# Altium schematic sheet ARK_CAN_GPS_Microcontroller.SchDoc(ARK_CAN_GPS_Microcontroller)
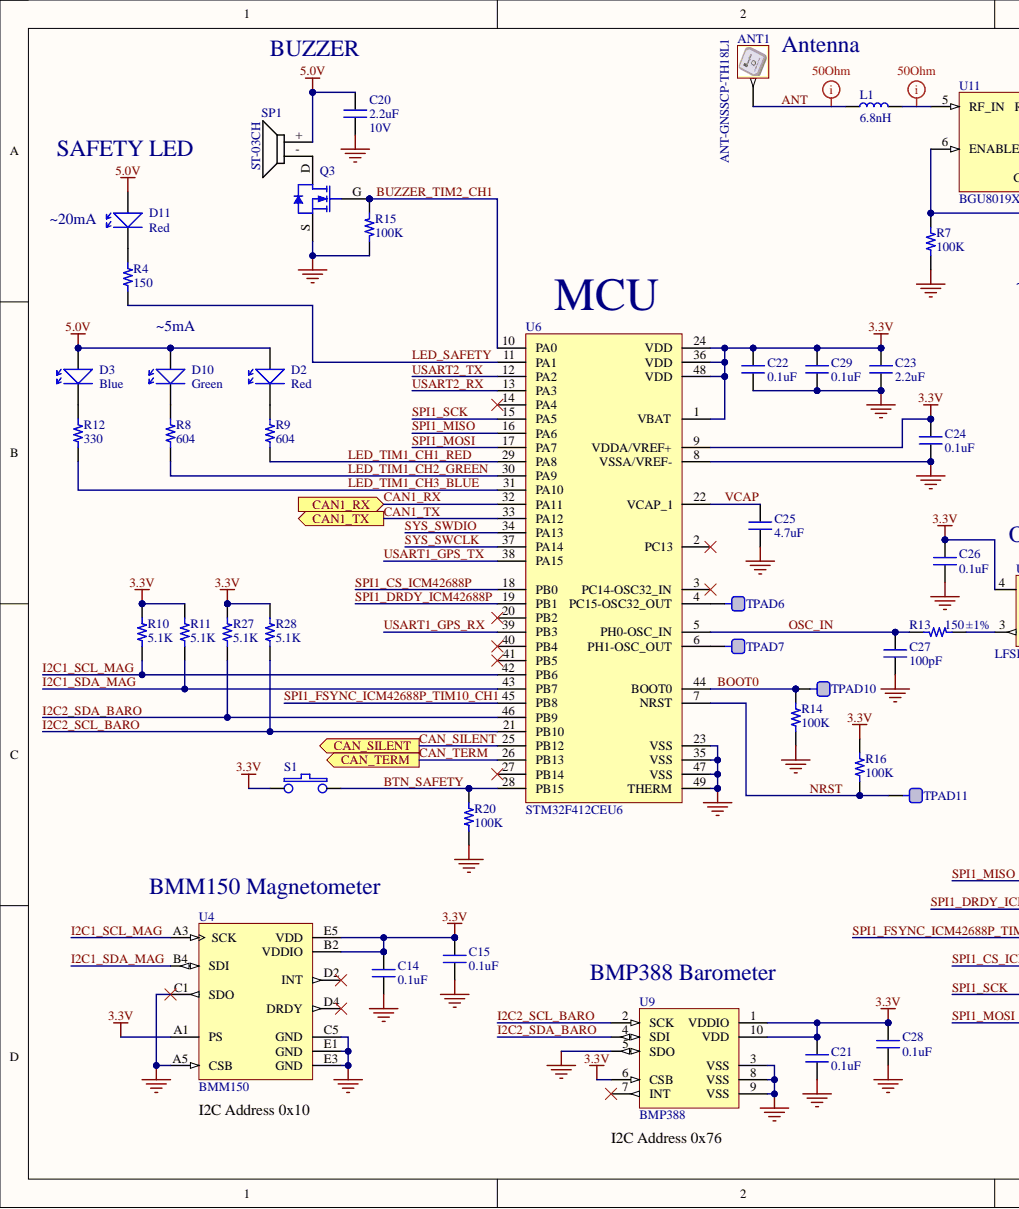
[diagram p0: ARK_CAN_GPS_Microcontroller.SchDoc, left]
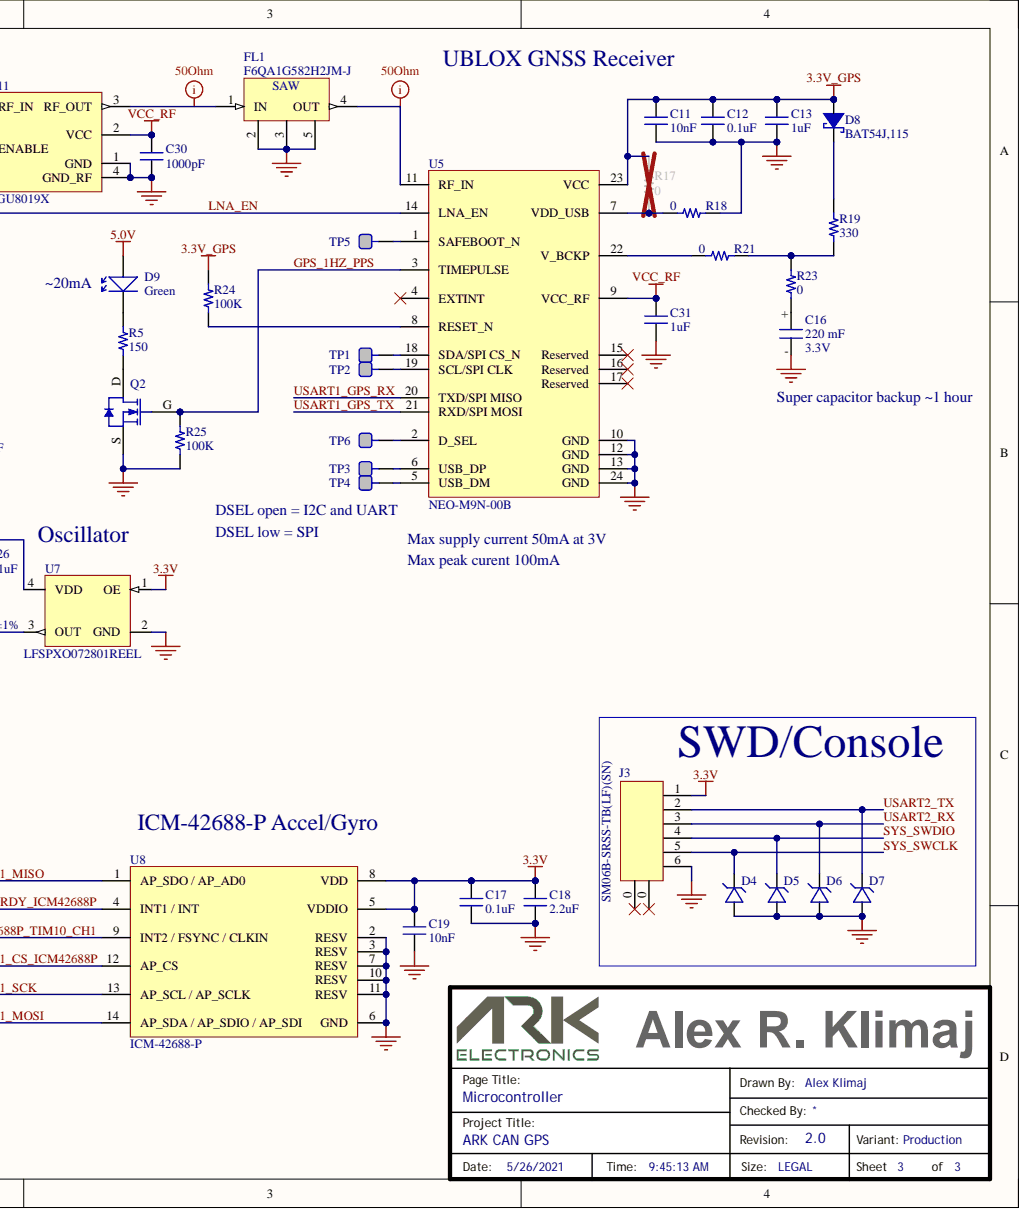
[diagram p1: ARK_CAN_GPS_Microcontroller.SchDoc, right]

## Parts
ANT1  ANT-GNSSCP-TH18L1  [ANT-GNSSCP-TH18L1]
C11  value 10nF  [CAP 0402/1005]  (hidden comment: Capacitor)
C12  0.1uF  [CAP 0402/1005]
C13  1uF  [CAP 0402/1005]
C14  0.1uF  [CAP 0402/1005]
C15  0.1uF  [CAP 0402/1005]
C16  value 220 mF  Voltage_Rating: 3.3V  [DSK-3R3H224U-HL]  (hidden comment: DCK-3R3E224U-E)
C17  0.1uF  [CAP 0402/1005]
C18  2.2uF  [CAP 0402/1005]
C19  10nF  [CAP 0402/1005]
C20  2.2uF  Voltage_Rating: 10V  [CAP 0402/1005]
C21  0.1uF  [CAP 0402/1005]
C22  value 0.1uF  [CAP 0402/1005]  (hidden comment: Capacitor)
C23  value 2.2uF  [CAP 0402/1005]  (hidden comment: Capacitor)
C24  value 0.1uF  [CAP 0402/1005]  (hidden comment: Capacitor)
C25  4.7uF  [CAP 0402/1005]
C26  value 0.1uF  [CAP 0402/1005]  (hidden comment: Capacitor)
C27  value 100pF  [CAP 0402/1005]  (hidden comment: Capacitor)
C28  0.1uF  [CAP 0402/1005]
C29  value 0.1uF  [CAP 0402/1005]  (hidden comment: Capacitor)
C30  1000pF  [CAP 0402/1005]
C31  1uF  [CAP 0402/1005]
D2  LTST-C190KRKT  [LTST-C191TBKT]  (value not drawn)
D3  LTST-C191TBKT  [LTST-C191TBKT]  (value not drawn)
D4  TVS Diode  [SOD-923]  (value not drawn)
D5  TVS Diode  [SOD-923]  (value not drawn)
D6  TVS Diode  [SOD-923]  (value not drawn)
D7  TVS Diode  [SOD-923]  (value not drawn)
D8  BAT54J,115  [SOD323]
D9  LTST-C191KGKT  [LTST-C191TBKT]  (value not drawn)
D10  LTST-C191KGKT  [LTST-C191TBKT]  (value not drawn)
D11  LTST-C190KRKT  [LTST-C191TBKT]  (value not drawn)
FL1  F6QA1G582H2JM-J  [F6QA1G582H2JM-J]
J3  SM06B-SRSS-TB(LF)(SN)  [SM06B-SRSS-TB(LF)(SN)]
L1  value 6.8nH  [Ind 0402/1005]
Q2  PMV130ENEAR  [SOT23 DIODES]  (value not drawn)
Q3  PMV130ENEAR  [SOT23 DIODES]  (value not drawn)
R4  150  [RESC0402(1005)_N]
R5  value 150  [RESC0402(1005)_N]  (hidden comment: Resistor)
R7  value 100K  [RESC0402(1005)_N]  (hidden comment: Resistor)
R8  604  [RESC0402(1005)_N]
R9  604  [RESC0402(1005)_N]
R10  value 5.1K  [RESC0402(1005)_N]  (hidden comment: Resistor)
R11  value 5.1K  [RESC0402(1005)_N]  (hidden comment: Resistor)
R12  330  [RESC0402(1005)_N]
R13  150  Tolerance: ±1%  [RESC0402(1005)_N]
R14  100K  [RESC0402(1005)_N]
R15  value 100K  [RESC0402(1005)_N]  (hidden comment: Resistor)
R16  100K  [RESC0402(1005)_N]
R17  0  [RESC0402(1005)_N]
R18  0  [RESC0402(1005)_N]
R19  330  [RESC0402(1005)_N]
R20  value 100K  [RESC0402(1005)_N]  (hidden comment: Resistor)
R21  0  [RESC0402(1005)_N]
R23  0  [RESC0402(1005)_N]
R24  value 100K  [RESC0402(1005)_N]  (hidden comment: Resistor)
R25  value 100K  [RESC0402(1005)_N]  (hidden comment: Resistor)
R27  value 5.1K  [RESC0402(1005)_N]  (hidden comment: Resistor)
R28  value 5.1K  [RESC0402(1005)_N]  (hidden comment: Resistor)
S1  SW PUSHBUTTON  [PTS 647 SK38 SMTR2 LFS]  (value not drawn)
SP1  ST-03CH  [ST-03CH]
TP1  TEST_PAD  [TEST_PAD]  (value not drawn)  (no BOM)
TP2  TEST_PAD  [TEST_PAD]  (value not drawn)  (no BOM)
TP3  TEST_PAD  [TEST_PAD]  (value not drawn)  (no BOM)
TP4  TEST_PAD  [TEST_PAD]  (value not drawn)  (no BOM)
TP5  TEST_PAD  [TEST_PAD]  (value not drawn)  (no BOM)
TP6  TEST_PAD  [TEST_PAD]  (value not drawn)  (no BOM)
TPAD6  TEST_PAD  [TEST_PAD]  (value not drawn)  (no BOM)
TPAD7  TEST_PAD  [TEST_PAD]  (value not drawn)  (no BOM)
TPAD10  TEST_PAD  [TEST_PAD]  (value not drawn)  (no BOM)
TPAD11  TEST_PAD  [TEST_PAD]  (value not drawn)  (no BOM)
U4  BMM150  [XDCR_BMM150]
U5  NEO-M9N-00B  [NEO-D9S]
U6  STM32F412CEU6  [UFQFPN48]
U7  LFSPXO072801REEL  Voltage_Rating: 3.3V  [LFSPXO072801REEL]
U8  ICM-42688-P  [ICM-42688-P]
U9  BMP388  [BMP388]
U11  BGU8019X  [TSNP-6-2]

## Ports
CAN1_RX, CAN1_TX, CAN_SILENT, CAN_TERM

## Nets
3.3V: C14.2 C15.2 C17.2 C18.2 C19.2 C21.2 C22.2 C23.2 C24.2 C26.2 C28.2 C29.2 J3.1 R10.2 R11.2 R16.2 R27.2 R28.2 S1.2 U4.A1(PS) U4.B2(VDDIO) U4.E5(VDD) U6.1(VBAT) U6.9(VDDA/VREF+) U6.24(VDD) U6.36(VDD) U6.48(VDD) U7.1(OE) U7.4(VDD) U8.5(VDDIO) U8.8(VDD) U9.1(VDDIO) U9.6(CSB) U9.10(VDD)
3.3V_GPS: C11.2 C12.2 C13.2 D8.2 R17.2 R24.2 U5.23(VCC)
5.0V: C20.2 D2.2 D3.2 D9.2 D10.2 D11.2 SP1.+
GND: C11.1 C12.1 C13.1 C14.1 C15.1 C16.- C17.1 C18.1 C19.1 C20.1 C21.1 C22.1 C23.1 C24.1 C25.1 C26.1 C27.1 C28.1 C29.1 C30.1 C31.1 D4.2 D5.2 D6.2 D7.2 FL1.2(IN) FL1.3 FL1.5 J3.6 Q2.S Q3.S R7.1 R14.1 R15.2 R18.2 R20.1 R25.1 U4.A5(CSB) U4.C1(SDO) U4.C5(GND) U4.E1(GND) U4.E3(GND) U5.10(GND) U5.12(GND) U5.13(GND) U5.24(GND) U6.8(VSSA/VREF-) U6.23(VSS) U6.35(VSS) U6.47(VSS) U6.49(THERM) U7.2(GND) U8.2(RESV) U8.3(RESV) U8.6(GND) U8.7(RESV) U8.10(RESV) U8.11(RESV) U9.3(VSS) U9.5(SDO) U9.8(VSS) U9.9(VSS) U11.1(GND) U11.4(GND_RF)
VCC_RF: C30.2 C31.2 U5.9(VCC_RF) U11.2(VCC)
ANT: ANT1.1 L1.1
BOOT0: R14.2 TPAD10.1 U6.44(BOOT0)
BTN_SAFETY: R20.2 S1.1 U6.28(PB15)
BUZZER_TIM2_CH1: Q3.G R15.1 U6.10(PA0)
CAN1_RX: U6.32(PA11) ; port CAN1_RX
CAN1_TX: U6.33(PA12) ; port CAN1_TX
CAN_SILENT: U6.25(PB12) ; port CAN_SILENT
CAN_TERM: U6.26(PB13) ; port CAN_TERM
GPS_1HZ_PPS: Q2.G R25.2 U5.3(TIMEPULSE)
I2C1_SCL_MAG: R10.1 U4.A3(SCK) U6.42(PB6)
I2C1_SDA_MAG: R11.1 U4.B4(SDI) U6.43(PB7)
I2C2_SCL_BARO: R28.1 U6.21(PB10) U9.2(SCK)
I2C2_SDA_BARO: R27.1 U6.46(PB9) U9.4(SDI)
LED_SAFETY: R4.1 U6.11(PA1)
LED_TIM1_CH1_RED: R9.1 U6.29(PA8)
LED_TIM1_CH2_GREEN: R8.1 U6.30(PA9)
LED_TIM1_CH3_BLUE: R12.1 U6.31(PA10)
LNA_EN: R7.2 U5.14(LNA_EN) U11.6(ENABLE)
NRST: R16.1 TPAD11.1 U6.7(NRST)
OSC_IN: C27.2 R13.1 U6.5(PH0-OSC_IN)
SPI1_CS_ICM42688P: U6.18(PB0) U8.12(AP_CS)
SPI1_DRDY_ICM42688P: U6.19(PB1) U8.4(INT1_/_INT)
SPI1_FSYNC_ICM42688P_TIM10_CH1: U6.45(PB8) U8.9
SPI1_MISO: U6.16(PA6) U8.1(AP_SDO_/_AP_AD0)
SPI1_MOSI: U6.17(PA7) U8.14
SPI1_SCK: U6.15(PA5) U8.13(AP_SCL_/_AP_SCLK)
SYS_SWCLK: D4.1 J3.5 U6.37(PA14)
SYS_SWDIO: D5.1 J3.4 U6.34(PA13)
USART1_GPS_RX: U5.20(TXD/SPI_MISO) U6.39(PB3)
USART1_GPS_TX: U5.21(RXD/SPI_MOSI) U6.38(PA15)
USART2_RX: D6.1 J3.3 U6.13(PA3)
USART2_TX: D7.1 J3.2 U6.12(PA2)
VCAP: C25.2 U6.22(VCAP_1)
NetC16_+: C16.+ R23.1
NetD2_1: D2.1 R9.2
NetD3_1: D3.1 R12.2
NetD8_1: D8.1 R19.2
NetD9_1: D9.1 R5.2
NetD10_1: D10.1 R8.2
NetD11_1: D11.1 R4.2
NetFL1_1: FL1.1 U11.3(RF_OUT)
NetFL1_4: FL1.4(OUT) U5.11(RF_IN)
NetL1_2: L1.2 U11.5(RF_IN)
NetQ2_D: Q2.D R5.1
NetQ3_D: Q3.D SP1.-
NetR13_2: R13.2 U7.3(OUT)
NetR17_1: R17.1 R18.1 U5.7(VDD_USB)
NetR19_1: R19.1 R21.2 R23.2
NetR21_1: R21.1 U5.22(V_BCKP)
NetR24_1: R24.1 U5.8(RESET_N)
NetTP1_1: TP1.1 U5.18(SDA/SPI_CS_N)
NetTP2_1: TP2.1 U5.19(SCL/SPI_CLK)
NetTP3_1: TP3.1 U5.6(USB_DP)
NetTP4_1: TP4.1 U5.5(USB_DM)
NetTP5_1: TP5.1 U5.1(SAFEBOOT_N)
NetTP6_1: TP6.1 U5.2(D_SEL)
NetTPAD6_1: TPAD6.1 U6.4(PC15-OSC32_OUT)
NetTPAD7_1: TPAD7.1 U6.6(PH1-OSC_OUT)

Not connected: J3 0; U4 D2(INT) D4(DRDY); U5 4(EXTINT) 15(Reserved) 16(Reserved) 17(Reserved); U6 2(PC13) 3(PC14-OSC32_IN) 14(PA4) 20(PB2) 27(PB14) 40(PB4) 41(PB5); U9 7(INT)

## Library data of the parts
ANT1 = 31085: Manufacturer: Linx Technologies Inc.; Mfr Part Description: RF ANT GNSS PATCH L1 18X18 PIN; Part_Type: RF; Database Table Name: Parts; Power_Rating: 8 W; Updated By: aklimaj; Component Kind: Standard; ComponentLink1Description: Datasheet; ComponentLink1URL: https://linxtechnologies.com/wp/wp-content/uploads/ant-gnsscp-th18l1-ds.pdf; ComponentLink2Description: Supplier Part Details; ComponentLink2URL: https://www.digikey.com/en/products/detail/linx-technologies-inc/ANT-GNSSCP-TH18L1/12686184; Created_Date: 2021/05/04 14:26:32; ID: 31085; Library Name: Parts.DbLib; LifeCycle: New; Mfr Part Number: ANT-GNSSCP-TH18L1; RoHS: Yes; Supplier: Digi-Key; Supplier Part Number: 343-ANT-GNSSCP-TH18L1-ND; Updated_Date: 2021/05/04 15:27:27; Value: ANT-GNSSCP-TH18L1; Created By: aklimaj
C11 C19 = 30018: SMT Capacitor; Manufacturer: Samsung; Mfr Part Description: CAP CER 10000pF ±10% 25V X7R 0402 (1005 Metric); Mfr Part Number: CL05B103KA5NNNC; Database Table Name: Parts; Dielectric: X7R; Tolerance: ±10%; Updated By: aklimaj; Voltage_Rating: 25V; Component Kind: Standard; ComponentLink1Description: Datasheet; ComponentLink1URL: http://www.samsungsem.com/kr/support/product-search/mlcc/__icsFiles/afieldfile/2016/08/18/S_CL05B103KA5NNNC.pdf; ComponentLink2Description: Supplier Part Details; ComponentLink2URL: https://www.digikey.com/product-detail/en/samsung-electro-mechanics-america-inc/CL05B103KA5NNNC/1276-1057-1-ND/3889143; Created_Date: 2017/07/13 10:07:08; ID: 30018; Library Name: Parts.DbLib; LifeCycle: New; Package_Case: 0402 (1005 Metric); Part_Type: Capacitor; RoHS: Yes; Supplier: Digi-Key; Supplier Part Number: 1276-1057-1-ND; Temperature Range: -55C to +125C; Updated_Date: 2017/07/13 10:07:58; Created By: aklimaj
  C19: Value: 10nF
C12 C14 C15 C17 C21 C22 C24 C26 C28 C29 = 30303: SMT Capacitor; Manufacturer: Samsung Electro-Mechanics; Mfr Part Description: CAP CER 0.1uF ±10% 10V X5R 0402 (1005 Metric); Mfr Part Number: CL05A104KP5NNNC; Database Table Name: Parts; Dielectric: X5R; Tolerance: ±10%; Updated By: aklimaj; Voltage_Rating: 10V; Component Kind: Standard; ComponentLink1Description: Datasheet; ComponentLink1URL: http://www.samsungsem.com/kr/support/product-search/mlcc/CL05A104KP5NNNC.jsp; ComponentLink2Description: Supplier Part Details; ComponentLink2URL: https://www.digikey.com/product-detail/en/samsung-electro-mechanics/CL05A104KP5NNNC/1276-1022-1-ND/3889108; Created_Date: 2019/02/28 17:08:36; ID: 30303; Library Name: Parts.DbLib; LifeCycle: New; Package_Case: 0402 (1005 Metric); Part_Type: Capacitor; RoHS: Yes; Supplier: Digi-Key; Supplier Part Number: 1276-1022-1-ND; Temperature Range: -55C to +85C; Updated_Date: 2019/02/28 17:09:37; Created By: aklimaj
  C12 C14 C15 C17 C21 C28: Value: 0.1uF
C13 C31 = 30652: SMT Capacitor; Manufacturer: Samsung Electro-Mechanics; Mfr Part Description: CAP CER 1uF ±10% 10V X5R 0402 (1005 Metric); Mfr Part Number: CL05A105KP5NNNC; Database Table Name: Parts; Dielectric: X5R; Tolerance: ±10%; Updated By: aklimaj; Voltage_Rating: 10V; Component Kind: Standard; ComponentLink1Description: Datasheet; ComponentLink1URL: http://www.samsungsem.com/kr/support/product-search/mlcc/CL05A105KP5NNNC.jsp; ComponentLink2Description: Supplier Part Details; ComponentLink2URL: https://www.digikey.com/product-detail/en/0/1276-1076-1-ND; Created_Date: 2019/11/21 13:38:55; ID: 30652; Library Name: Parts.DbLib; LifeCycle: New; Package_Case: 0402 (1005 Metric); Part_Type: Capacitor; RoHS: Yes; Supplier: Digi-Key; Supplier Part Number: 1276-1076-1-ND; Temperature Range: -55C to +85C; Updated_Date: 2020/08/31 12:38:02; Value: 1uF; Created By: aklimaj
C16 = 31092: Manufacturer: Elna America; Mfr Part Description: CAP 220 mF -20%, +80% 3.3V Coin, Wide Terminals - Opposite Sides; Part_Type: Capacitor; Database Table Name: Parts; Tolerance: -20%, +80%; Updated By: aklimaj; Component Kind: Standard; ComponentLink1Description: Datasheet; ComponentLink1URL: https://media.digikey.com/pdf/Data%20Sheets/Elna%20America%20Inc/DS,DSK.pdf; ComponentLink2Description: Supplier Part Details; ComponentLink2URL: https://www.digikey.com/en/products/detail/elna-america/DSK-3R3H224U-HL/970181?s=N4IgTCBcDaIGwAYAsBaAjAsD0oHIBEQBdAXyA; Created_Date: 2021/05/23 17:43:09; ID: 31092; Library Name: Parts.DbLib; LifeCycle: New; Mfr Part Number: DSK-3R3H224U-HL; Package_Case: Coin, Wide Terminals - Opposite Sides; RoHS: Yes; Supplier: Digi-Key; Supplier Part Number: 604-1020-2-ND; Temperature Range: -10C to +60C; Updated_Date: 2021/05/23 18:11:51; Created By: aklimaj
C18 C23 = 30151: SMT Capacitor; Manufacturer: Murata; Mfr Part Description: CAP CER 2.2uF ±20% 10V X5R 0402 (1005 Metric); Mfr Part Number: GRM155R61A225ME95D; Database Table Name: Parts; Dielectric: X5R; Tolerance: ±20%; Updated By: aklimaj; Voltage_Rating: 10V; Component Kind: Standard; ComponentLink1Description: Datasheet; ComponentLink1URL: http://search.murata.co.jp/Ceramy/image/img/A01X/partnumbering_e_01.pdf; ComponentLink2Description: Supplier Part Details; ComponentLink2URL: https://www.digikey.com/product-detail/en/murata-electronics-north-america/GRM155R61A225ME95D/490-10452-2-ND/5027537; Created_Date: 2017/11/02 14:41:38; ID: 30151; Library Name: Parts.DbLib; LifeCycle: New; Package_Case: 0402 (1005 Metric); Part_Type: Capacitor; RoHS: Yes; Supplier: Digi-Key; Supplier Part Number: 490-10452-2-ND; Temperature Range: -55C to +85C; Updated_Date: 2018/10/19 21:38:16; Created By: aklimaj
  C18: Value: 2.2uF
C20 = 30151: SMT Capacitor; Manufacturer: Murata; Mfr Part Description: CAP CER 2.2uF ±20% 10V X5R 0402 (1005 Metric); Mfr Part Number: GRM155R61A225ME95D; Database Table Name: Parts; Dielectric: X5R; Tolerance: ±20%; Updated By: aklimaj; Component Kind: Standard; ComponentLink1Description: Datasheet; ComponentLink1URL: http://search.murata.co.jp/Ceramy/image/img/A01X/partnumbering_e_01.pdf; ComponentLink2Description: Supplier Part Details; ComponentLink2URL: https://www.digikey.com/product-detail/en/murata-electronics-north-america/GRM155R61A225ME95D/490-10452-2-ND/5027537; Created_Date: 2017/11/02 14:41:38; ID: 30151; Library Name: Parts.DbLib; LifeCycle: New; Package_Case: 0402 (1005 Metric); Part_Type: Capacitor; RoHS: Yes; Supplier: Digi-Key; Supplier Part Number: 490-10452-2-ND; Temperature Range: -55C to +85C; Updated_Date: 2018/10/19 21:38:16; Value: 2.2uF; Created By: aklimaj
C25 = 30302: SMT Capacitor; Manufacturer: TDK Corporation; Mfr Part Description: CAP CER 4.7uF ±10% 6.3V X5R 0402 (1005 Metric); Mfr Part Number: C1005X5R0J475K050BC; Database Table Name: Parts; Dielectric: X5R; Tolerance: ±10%; Updated By: aklimaj; Voltage_Rating: 6.3V; Component Kind: Standard; ComponentLink1Description: Datasheet; ComponentLink1URL: https://product.tdk.com/info/en/catalog/datasheets/mlcc_commercial_general_en.pdf; ComponentLink2Description: Supplier Part Details; ComponentLink2URL: https://www.digikey.com/products/en?keywords=445-5947-1-ND&stock=1; Created_Date: 2019/02/28 17:07:10; ID: 30302; Library Name: Parts.DbLib; LifeCycle: New; Package_Case: 0402 (1005 Metric); Part_Type: Capacitor; RoHS: Yes; Supplier: Digi-Key; Supplier Part Number: 445-5947-1-ND; Temperature Range: -55C to +85C; Updated_Date: 2019/02/28 17:07:25; Value: 4.7uF; Created By: aklimaj
C27 = 30502: SMT Capacitor; Manufacturer: Samsung Electro-Mechanics; Mfr Part Description: CAP CER 100pF ±5% 50V NPO 0402 (1005 Metric); Mfr Part Number: CL05C101JB5NNNC; Database Table Name: Parts; Dielectric: NPO; Tolerance: ±5%; Updated By: aklimaj; Voltage_Rating: 50V; Component Kind: Standard; ComponentLink1Description: Datasheet; ComponentLink1URL: http://www.samsungsem.com/kr/support/product-search/mlcc/CL05C101JB5NNNC.jsp; ComponentLink2Description: Supplier Part Details; ComponentLink2URL: https://www.digikey.com/product-detail/en/samsung-electro-mechanics/CL05C101JB5NNNC/1276-1025-1-ND/3889111; Created_Date: 2019/07/13 19:13:16; ID: 30502; Library Name: Parts.DbLib; LifeCycle: New; Package_Case: 0402 (1005 Metric); Part_Type: Capacitor; RoHS: Yes; Supplier: Digi-Key; Supplier Part Number: 1276-1025-1-ND; Temperature Range: -55C to +125C; Updated_Date: 2019/07/13 19:13:27; Created By: aklimaj
C30 = 30011: SMT Capacitor; Manufacturer: Murata; Mfr Part Description: CAP CER 1000pF ±5% 50V X7R 0402 (1005 Metric); Mfr Part Number: GRM155R71H102JA01J; Database Table Name: Parts; Dielectric: X7R; Tolerance: ±5%; Updated By: aklimaj; Voltage_Rating: 50V; Component Kind: Standard; ComponentLink1Description: Datasheet; ComponentLink1URL: http://search.murata.co.jp/Ceramy/image/img/A01X/partnumbering_e_01.pdf; ComponentLink2Description: Supplier Part Details; ComponentLink2URL: https://www.digikey.com/product-detail/en/murata-electronics-north-america/GRM155R71H102JA01J/490-6349-1-ND/3845546; Created_Date: 2017/07/12 15:36:45; ID: 30011; Library Name: Parts.DbLib; LifeCycle: New; Package_Case: 0402 (1005 Metric); Part_Type: Capacitor; RoHS: Yes; Supplier: Digi-Key; Supplier Part Number: 490-6349-1-ND; Temperature Range: -55C to +125C; Updated_Date: 2017/07/12 15:36:59; Value: 1000pF; Created By: aklimaj
D2 D11 = 30943: Manufacturer: Lite-On Inc.; Mfr Part Description: LED RED CLEAR CHIP SMD; Part_Type: Discrete; Current_Rating: 20mA; Database Table Name: Parts; Updated By: aklimaj; Voltage_Rating: 2V; Component Kind: Standard; ComponentLink1Description: Datasheet; ComponentLink1URL: http://optoelectronics.liteon.com/upload/download/DS-22-99-0151/LTST-C190KRKT.pdf; ComponentLink2Description: Supplier Part Details; ComponentLink2URL: https://www.digikey.com/products/en?keywords=LTST-C190KRKT; Created_Date: 2020/12/11 17:10:57; ID: 30943; Library Name: Parts.DbLib; LifeCycle: New; Mfr Part Number: LTST-C190KRKT; Package_Case: 0603 (1608 Metric); RoHS: Yes; Supplier: Digi-Key; Supplier Part Number: 160-1436-2-ND; Updated_Date: 2020/12/11 17:11:02; Value: LTST-C190KRKT; Created By: aklimaj
D3 = 30059: LTST-C191TBKT LED BLUE CLEAR 0603 SMD; Manufacturer: Lite-On Inc.; Part_Type: Discrete; Current_Rating: 20mA; Database Table Name: Parts; Updated By: aklimaj; Voltage_Rating: 3.3V; Component Kind: Standard; ComponentLink1Description: Datasheet; ComponentLink1URL: http://optoelectronics.liteon.com/upload/download/DS22-2000-230/LTST-C191TBKT.pdf; ComponentLink2Description: Supplier Part Details; ComponentLink2URL: https://www.digikey.com/product-detail/en/lite-on-inc/LTST-C191TBKT/160-1647-1-ND/573587; Created_Date: 2017/09/05 17:40:57; ID: 30059; Library Name: Parts.DbLib; LifeCycle: New; Mfr Part Description: LED BLUE CLEAR 0603 SMD; Mfr Part Number: LTST-C191TBKT; Package_Case: 0603 (1608 Metric); RoHS: Yes; Supplier: Digi-Key; Supplier Part Number: 160-1647-1-ND; Updated_Date: 2018/10/19 21:38:38; Value: LTST-C191TBKT; Created By: aklimaj
D4-D7 = 30166: Manufacturer: ON Semiconductor; Mfr Part Description: TVS DIODE 3.3VWM SOD923; Mfr Part Number: ESD9C3.3ST5G; Part_Type: Protection; Database Table Name: Parts; Updated By: aklimaj; Value: ESD9C3.3ST5G; Voltage_Rating: 3.3V (Max); Component Kind: Standard; ComponentLink1Description: Datasheet; ComponentLink1URL: http://www.onsemi.com/pub/Collateral/ESD9C3.3S-D.PDF; ComponentLink2Description: Supplier Part Details; ComponentLink2URL: https://www.digikey.com/product-detail/en/on-semiconductor/ESD9C3.3ST5G/ESD9C3.3ST5GOSCT-ND/1646498; Created_Date: 2017/12/13 10:54:56; Description: TVS Diode; ID: 30166; Library Name: Parts.DbLib; LifeCycle: New; Package_Case: SOD-923; RoHS: Yes; Supplier: Digi-Key; Supplier Part Number: ESD9C3.3ST5GOSCT-ND; Temperature Range: -55C to +150C (TJ); Updated_Date: 2018/10/19 21:38:12; Created By: aklimaj
D8 = 30022: Diode Schottky 30V 200mA (DC) Surface Mount SOD-323F; Manufacturer: Nexperia USA Inc.; Mfr Part Description: DIODE SCHOTTKY 30V 200MA SOD323F; Part_Type: Discrete; Capacitance @ Vr, F: 10pF @ 1V, 1MHz; Current - Reverse Leakage @ Vr: 2µA @ 25V; Database Table Name: Parts; Speed: Small Signal =< 200mA (Io), Any Speed; Updated By: aklimaj; Voltage - Forward (Vf) (Max) @ If: 800mV @ 100mA; Category: Discrete Semiconductor Products; Component Kind: Standard; ComponentLink1Description: Datasheet; ComponentLink1URL: http://assets.nexperia.com/documents/data-sheet/BAT54J.pdf; ComponentLink2Description: Supplier Part Details; ComponentLink2URL: https://www.digikey.com/products/en?keywords=1727-4818-6-ND&stock=1; Created_Date: 2017/07/13 11:14:24; Current - Average Rectified (Io): 200mA (DC); Current_Rating: 200mA (DC); Diode Type: Schottky; ID: 30022; Library Name: Parts.DbLib; LifeCycle: New; Manufacturer Part Number: BAT54J,115; Mfr Part Number: BAT54J,115; Mounting Type: Surface Mount; Operating Temperature - Junction: 150°C (Max); Package / Case: SC-90, SOD-323F; Package_Case: SC-90, SOD-323F; Packaging: Digi-Reel®; Part Status: Active; Pricing 1: 1=0.37, 10=0.273, 100=0.1545, 500=0.1023, 1000=0.07843 (USD); RoHS: Yes; Stock 1: 25340; Supplier: Digi-Key; Supplier 1: Digi-Key; Supplier Device Package: SOD-323F; Supplier Part Number: 1727-4818-6-ND; Supplier Part Number 1: 1727-4818-6-ND; Temperature Range: 150C (Max); Updated_Date: 2017/07/13 11:17:36; Value: BAT54J,115; Voltage - DC Reverse (Vr) (Max): 30V; Voltage_Rating: 30V; Created By: aklimaj
D9 D10 = 30628: LTST-C191TBKT LED BLUE CLEAR 0603 SMD; Manufacturer: Lite-On Inc.; Mfr Part Description: Green 571nm LED Indication - Discrete 2V 0603 (1608 Metric); Part_Type: Discrete; Current_Rating: 20mA; Database Table Name: Parts; Updated By: aklimaj; Voltage_Rating: 2V; Component Kind: Standard; ComponentLink1Description: Datasheet; ComponentLink1URL: http://optoelectronics.liteon.com/upload/download/DS22-2000-228/LTST-C191KGKT.PDF; ComponentLink2Description: Supplier Part Details; ComponentLink2URL: https://www.digikey.com/product-detail/en/lite-on-inc/LTST-C191KGKT/160-1446-1-ND/386834; Created_Date: 2019/11/13 17:03:39; ID: 30628; Library Name: Parts.DbLib; LifeCycle: New; Mfr Part Number: LTST-C191KGKT; Package_Case: 0603 (1608 Metric); RoHS: Yes; Supplier: Digi-Key; Supplier Part Number: 160-1446-1-ND; Updated_Date: 2019/11/13 17:03:43; Value: LTST-C191KGKT; Created By: aklimaj
FL1 = 30001: F6QA1G582H2JM-J FILTER SAW GPS+GLONASS+COMPASS; Manufacturer: Taiyo Yuden; Database Table Name: Parts; Updated By: aklimaj; Component Kind: Standard; ComponentLink1Description: Datasheet; ComponentLink1URL: http://www.yuden.co.jp/productdata/catalog/en/sawfilter01_e.pdf; ComponentLink2Description: Supplier Part Details; ComponentLink2URL: https://www.digikey.com/product-detail/en/taiyo-yuden/F6QA1G582H2JM-J/587-3990-1-ND/4963980; Created_Date: 2017/07/10 17:26:24; ID: 30001; Library Name: Parts.DbLib; LifeCycle: New; Mfr Part Description: FILTER SAW GPS+GLONASS+COMPASS; Mfr Part Number: F6QA1G582H2JM-J; Package_Case: 5-SMD, No Lead; Part_Type: Filter; RoHS: Yes; Supplier: Digi-Key; Supplier Part Number: 587-3990-1-ND; Updated_Date: 2017/07/12 14:43:03; Value: F6QA1G582H2JM-J; Created By: aklimaj
J3 = 30085: CONN HEADER SH 6POS SIDE 1MM TIN; Manufacturer: JST Sales America Inc.; Part_Type: Connector; Current_Rating: 0.7A; Database Table Name: Parts; Updated By: aklimaj; Voltage_Rating: 50V; Component Kind: Standard; ComponentLink1Description: Datasheet; ComponentLink1URL: http://www.jst-mfg.com/product/pdf/eng/eSH.pdf; ComponentLink2Description: Supplier Part Details; ComponentLink2URL: https://www.digikey.com/product-detail/en/jst-sales-america-inc/SM06B-SRSS-TB(LF)(SN)/455-1806-1-ND/926877; Created_Date: 2017/09/13 10:26:52; ID: 30085; Library Name: Parts.DbLib; LifeCycle: New; Mfr Part Description: CONN HEADER SH 6POS SIDE 1MM TIN; Mfr Part Number: SM06B-SRSS-TB(LF)(SN); RoHS: Yes; Supplier: Digi-Key; Supplier Part Number: 455-1806-1-ND; Temperature Range: -25C to +85C; Updated_Date: 2018/10/19 21:38:32; Value: SM06B-SRSS-TB(LF)(SN); Created By: aklimaj
L1 = 30947: SMT Inductor; Manufacturer: Murata Electronics; Mfr Part Description: INDUCTOR 6.8nH ±2% 1.45A 0402 (1005 Metric); Mfr Part Number: LQW15AN6N8G80D; Current_Rating: 1.45A; Database Table Name: Parts; Tolerance: ±2%; Updated By: aklimaj; Component Kind: Standard; ComponentLink1Description: Datasheet; ComponentLink1URL: https://search.murata.co.jp/Ceramy/image/img/P02/JELF243A-0100.pdf; ComponentLink2Description: Supplier Part Details; ComponentLink2URL: https://www.digikey.com/products/en?keywords=LQW15AN6N8G80D; Created_Date: 2020/12/18 17:42:21; ID: 30947; Library Name: Parts.DbLib; LifeCycle: New; Package_Case: 0402 (1005 Metric); Part_Type: Inductor; RoHS: Yes; Supplier: Digi-Key; Supplier Part Number: 490-14714-2-ND; Temperature Range: -55C to +125C; Updated_Date: 2020/12/18 17:47:41; Created By: aklimaj
Q2 Q3 = 31093: Manufacturer: Nexperia USA Inc.; Mfr Part Description: MOSFET N-CH 40V 2.1A TO236AB; Part_Type: Discrete; Current_Rating: 2.1A (Ta); Database Table Name: Parts; Updated By: aklimaj; Voltage_Rating: 4.5V, 10V; Component Kind: Standard; ComponentLink1Description: Datasheet; ComponentLink1URL: https://assets.nexperia.com/documents/data-sheet/PMV130ENEA.pdf; ComponentLink2Description: Supplier Part Details; ComponentLink2URL: https://www.digikey.com/en/products/detail/nexperia-usa-inc/PMV130ENEAR/5395790; Created_Date: 2021/05/26 09:10:32; ID: 31093; Library Name: Parts.DbLib; LifeCycle: New; Mfr Part Number: PMV130ENEAR; Package_Case: TO-236-3, SC-59, SOT-23-3; RoHS: Yes; Supplier: Digi-Key; Supplier Part Number: 1727-2299-2-ND; Temperature Range: -55C to +150C (TJ); Updated_Date: 2021/05/26 09:10:42; Value: PMV130ENEAR; Created By: aklimaj
R4 R5 = 30328: SMT Resistor; Manufacturer: Yageo; Mfr Part Description: RES 150 Ohm ±1% 1/16W 0402 (1005 Metric); Mfr Part Number: RC0402FR-07150RL; Database Table Name: Parts; Power_Rating: 0.063W, 1/16W; Temperature Coeff: ±100ppm/degC; Tolerance: ±1%; Updated By: aklimaj; Component Kind: Standard; ComponentLink1Description: Datasheet; ComponentLink1URL: https://www.yageo.com/upload/media/product/productsearch/datasheet/rchip/PYu-RC_Group_51_RoHS_L_11.pdf; ComponentLink2Description: Supplier Part Details; ComponentLink2URL: https://www.digikey.com/en/products/detail/yageo/RC0402FR-07150RL/726543; Created_Date: 2019/02/28 18:44:46; ID: 30328; Library Name: Parts.DbLib; LifeCycle: New; Package_Case: 0402 (1005 Metric); Part_Type: Resistor; RoHS: Yes; Supplier: Digi-Key; Supplier Part Number: 311-150LRTR-ND; Temperature Range: -55C to +155C; Updated_Date: 2021/05/26 09:40:11; Created By: aklimaj
  R4: Value: 150
R7 R14-R16 R20 R24 R25 = 30333: SMT Resistor; Manufacturer: Yageo; Mfr Part Description: RES 100K Ohm ±5% 1/16W 0402 (1005 Metric); Mfr Part Number: AC0402JR-07100KL; Database Table Name: Parts; Power_Rating: 0.063W, 1/16W; Temperature Coeff: ±100ppm/degC; Tolerance: ±5%; Updated By: aklimaj; Component Kind: Standard; ComponentLink1Description: Datasheet; ComponentLink1URL: http://www.yageo.com/NewPortal/yageodocoutput?fileName=/pdf/R-Chip/PYu-AC_51_RoHS_L_6.pdf; ComponentLink2Description: Supplier Part Details; ComponentLink2URL: https://www.digikey.com/products/en?keywords=YAG3515CT-ND&stock=1; Created_Date: 2019/02/28 18:55:55; ID: 30333; Library Name: Parts.DbLib; LifeCycle: New; Package_Case: 0402 (1005 Metric); Part_Type: Resistor; RoHS: Yes; Supplier: Digi-Key; Supplier Part Number: YAG3515CT-ND; Temperature Range: -55C to +155C; Updated_Date: 2019/02/28 18:56:10; Created By: aklimaj
  R14 R16: Value: 100K
R8 R9 = 30838: SMT Resistor; Manufacturer: Stackpole Electronics Inc; Mfr Part Description: RES 604 Ohm ±1% 1/16W 0402 (1005 Metric); Mfr Part Number: RMCF0402FT604R; Database Table Name: Parts; Power_Rating: 0.063W, 1/16W; Temperature Coeff: ±100ppm/degC; Tolerance: ±1%; Updated By: aklimaj; Component Kind: Standard; ComponentLink1Description: Datasheet; ComponentLink1URL: https://www.seielect.com/catalog/sei-rmcf_rmcp.pdf; ComponentLink2Description: Supplier Part Details; ComponentLink2URL: https://www.digikey.com/product-detail/en/stackpole-electronics-inc/RMCF0402FT604R/RMCF0402FT604RCT-ND/6052942; Created_Date: 2020/07/23 10:53:25; ID: 30838; Library Name: Parts.DbLib; LifeCycle: New; Package_Case: 0402 (1005 Metric); Part_Type: Resistor; RoHS: Yes; Supplier: Digi-Key; Supplier Part Number: RMCF0402FT604RCT-ND; Temperature Range: -55C to +155C; Updated_Date: 2020/07/23 10:53:37; Value: 604; Created By: aklimaj
R10 R11 R27 R28 = 30207: SMT Resistor; Manufacturer: Yageo; Mfr Part Description: RES 5.1K Ohm ±1% 1/16W 0402 (1005 Metric); Mfr Part Number: RC0402FR-075K1L; Database Table Name: Parts; Power_Rating: 0.063W, 1/16W; Temperature Coeff: ±100ppm/degC; Tolerance: ±1%; Updated By: aklimaj; Component Kind: Standard; ComponentLink1Description: Datasheet; ComponentLink1URL: http://www.yageo.com/documents/recent/PYu-RC_Group_51_RoHS_L_9.pdf; ComponentLink2Description: Supplier Part Details; ComponentLink2URL: https://www.digikey.com/product-detail/en/yageo/RC0402FR-075K1L/311-5.10KLRCT-ND/729571; Created_Date: 2018/05/21 18:16:47; ID: 30207; Library Name: Parts.DbLib; LifeCycle: New; Package_Case: 0402 (1005 Metric); Part_Type: Resistor; RoHS: Yes; Supplier: Digi-Key; Supplier Part Number: 311-5.10KLRCT-ND; Temperature Range: -55C to +155C; Updated_Date: 2018/10/19 21:38:02; Created By: aklimaj
R12 R19 = 30681: SMT Resistor; Manufacturer: Yageo; Mfr Part Description: RES 330 Ohm ±1% 1/16W 0402 (1005 Metric); Mfr Part Number: RC0402FR-07330RL; Database Table Name: Parts; Power_Rating: 0.063W, 1/16W; Temperature Coeff: ±100ppm/degC; Tolerance: ±1%; Updated By: aklimaj; Component Kind: Standard; ComponentLink1Description: Datasheet; ComponentLink1URL: https://www.yageo.com/upload/media/product/productsearch/datasheet/rchip/PYu-RC_Group_51_RoHS_L_10.pdf; ComponentLink2Description: Supplier Part Details; ComponentLink2URL: https://www.digikey.com/product-detail/en/yageo/RC0402FR-07330RL/311-330LRCT-ND/729541; Created_Date: 2019/12/02 20:26:12; ID: 30681; Library Name: Parts.DbLib; LifeCycle: New; Package_Case: 0402 (1005 Metric); Part_Type: Resistor; RoHS: Yes; Supplier: Digi-Key; Supplier Part Number: 311-330LRCT-ND; Temperature Range: -55C to +155C; Updated_Date: 2019/12/02 20:28:13; Value: 330; Created By: aklimaj
R13 = 30328: SMT Resistor; Manufacturer: Yageo; Mfr Part Description: RES 150 Ohm ±1% 1/16W 0402 (1005 Metric); Mfr Part Number: RC0402FR-07150RL; Database Table Name: Parts; Power_Rating: 0.063W, 1/16W; Temperature Coeff: ±100ppm/degC; Updated By: aklimaj; Component Kind: Standard; ComponentLink1Description: Datasheet; ComponentLink1URL: https://www.yageo.com/upload/media/product/productsearch/datasheet/rchip/PYu-RC_Group_51_RoHS_L_11.pdf; ComponentLink2Description: Supplier Part Details; ComponentLink2URL: https://www.digikey.com/en/products/detail/yageo/RC0402FR-07150RL/726543; Created_Date: 2019/02/28 18:44:46; ID: 30328; Library Name: Parts.DbLib; LifeCycle: New; Package_Case: 0402 (1005 Metric); Part_Type: Resistor; RoHS: Yes; Supplier: Digi-Key; Supplier Part Number: 311-150LRTR-ND; Temperature Range: -55C to +155C; Updated_Date: 2021/05/26 09:40:11; Value: 150; Created By: aklimaj
R17 R18 R21 R23 = 30327: SMT Resistor; Manufacturer: Yageo; Mfr Part Description: RES 0 Ohm Jumper 1/16W 0402 (1005 Metric); Mfr Part Number: AC0402JR-070RL; Database Table Name: Parts; Power_Rating: 0.063W, 1/16W; Tolerance: Jumper; Updated By: aklimaj; Component Kind: Standard; ComponentLink1Description: Datasheet; ComponentLink1URL: https://www.yageo.com/upload/media/product/productsearch/datasheet/rchip/PYu-AC_51_RoHS_L_7.pdf; ComponentLink2Description: Supplier Part Details; ComponentLink2URL: https://www.digikey.com/product-detail/en/AC0402JR-070RL/311-0.0LBCT-ND; Created_Date: 2019/02/28 18:42:38; ID: 30327; Library Name: Parts.DbLib; LifeCycle: New; Package_Case: 0402 (1005 Metric); Part_Type: Resistor; RoHS: Yes; Supplier: Digi-Key; Supplier Part Number: 311-0.0LBCT-ND; Temperature Range: -55C to +155C; Updated_Date: 2020/05/04 13:23:15; Value: 0; Created By: aklimaj
S1 = 31020: Manufacturer: C&ampK; Mfr Part Description: TACT 4.5 X 4.5, 3.8 MM H, 2.5N,; Part_Type: Switch; Database Table Name: Parts; Updated By: aklimaj; Value: PTS 647 SK38 SMTR2 LFS; Voltage_Rating: 0.05A @ 12VDC; Component Kind: Standard; ComponentLink1Description: Datasheet; ComponentLink1URL: https://www.ckswitches.com/media/2567/pts647.pdf; ComponentLink2Description: Supplier Part Details; ComponentLink2URL: https://www.digikey.com/products/en?keywords=PTS 647 SK38 SMTR2 LFS; Created_Date: 2021/03/25 22:33:30; ID: 31020; Library Name: Parts.DbLib; LifeCycle: New; Mfr Part Number: PTS 647 SK38 SMTR2 LFS; RoHS: Yes; Supplier: Digi-Key; Supplier Part Number: PTS647SK38SMTR2LFSTR-ND; Temperature Range: -20C to +70C; Updated_Date: 2021/03/25 22:42:03; Created By: aklimaj
SP1 = 30093: AUDIO MAGNETIC XDCR 4-6V SMD; Manufacturer: Soberton Inc.; Current_Rating: 100mA; Database Table Name: Parts; Updated By: aklimaj; Voltage_Rating: 5V; Component Kind: Standard; ComponentLink1Description: Datasheet; ComponentLink1URL: https://www.soberton.com/wp-content/uploads/2019/02/ST-03CH-19-Feb-2019.pdf; ComponentLink2Description: Supplier Part Details; ComponentLink2URL: https://www.digikey.com/product-detail/en/ST-03CH/433-1007-1-ND; Created_Date: 2017/09/13 12:26:07; ID: 30093; Library Name: Parts.DbLib; LifeCycle: New; Mfr Part Description: AUDIO MAGNETIC XDCR 4-6V SMD; Mfr Part Number: ST-03CH; Part_Type: AUDIO; RoHS: Yes; Supplier: Digi-Key; Supplier Part Number: 433-1007-1-ND; Temperature Range: -30C to +70C; Updated_Date: 2020/06/01 14:49:47; Value: ST-03CH; Created By: aklimaj
TP1-TP6 TPAD6 TPAD7 TPAD10 TPAD11 = 30015: Mfr Part Description: Test Point; Database Table Name: Parts; Updated By: aklimaj; ComponentLink1Description: Datasheet; ComponentLink2Description: Supplier Part Details; Created_Date: 2017/07/13 09:26:35; Description: TEST_PAD; ID: 30015; Library Name: Parts.DbLib; LifeCycle: New; Part_Type: Test Point; RoHS: Yes; Updated_Date: 2019/07/19 12:21:04; Created By: aklimaj
U4 = 30801: Manufacturer: Bosch Sensortec; Mfr Part Description: SENSOR FLIPCORE/HALL SPI 12WLCSP; Part_Type: SENSOR; Current_Rating: 20mA; Database Table Name: Parts; Updated By: aklimaj; Voltage_Rating: 1.62V ~ 3.6V; Component Kind: Standard; ComponentLink1Description: Datasheet; ComponentLink1URL: https://ae-bst.resource.bosch.com/media/_tech/media/datasheets/BST-BMM150-DS001.pdf; ComponentLink2Description: Supplier Part Details; ComponentLink2URL: https://www.digikey.com/product-detail/en/bosch-sensortec/BMM150/828-1044-1-ND/4196683; Created_Date: 2020/06/17 17:06:43; ID: 30801; Library Name: Parts.DbLib; LifeCycle: New; Mfr Part Number: BMM150; Package_Case: 12-XFBGA, WLCSP; RoHS: Yes; Supplier: Digi-Key; Supplier Part Number: 828-1044-1-ND; Temperature Range: -40C to +85C (TA); Updated_Date: 2020/06/17 17:15:31; Value: BMM150; Created By: aklimaj
U5 = 31012: Manufacturer: U-Blox; Mfr Part Description: M9 STANDARD PRECISION GNSS MOD; Part_Type: M9; Database Table Name: Parts; Updated By: aklimaj; Voltage_Rating: 2.7V ~ 3.6V; Component Kind: Standard; ComponentLink1Description: Datasheet; ComponentLink1URL: https://www.u-blox.com/sites/default/files/NEO-M9N_DataSheet_%28UBX-19014285%29.pdf; ComponentLink2Description: Supplier Part Details; ComponentLink2URL: https://www.digikey.com/products/en?keywords=NEO-M9N-00B; Created_Date: 2021/03/20 15:06:36; ID: 31012; Library Name: Parts.DbLib; LifeCycle: New; Mfr Part Number: NEO-M9N-00B; Package_Case: 24-SMD Module; RoHS: Yes; Supplier: Digi-Key; Supplier Part Number: 672-NEO-M9N-00BTR-ND; Temperature Range: -40C to +85C; Updated_Date: 2021/03/20 15:09:24; Value: NEO-M9N-00B; Created By: aklimaj
U6 = 30579: Manufacturer: STMicroelectronics; Mfr Part Description: ARM® Cortex®-M4 STM32F4 Microcontroller IC 32-Bit 100MHz 512KB (512K x 8) FLASH 48-UFQFPN (7x7); Part_Type: IC; Database Table Name: Parts; Updated By: aklimaj; Component Kind: Standard; ComponentLink1Description: Datasheet; ComponentLink1URL: http://www.st.com/content/ccc/resource/technical/document/datasheet/15/90/07/b3/8c/10/4e/95/DM00213872.pdf/files/DM00213872.pdf/jcr:content/translations/en.DM00213872.pdf; ComponentLink2Description: Supplier Part Details; ComponentLink2URL: https://www.digikey.com/product-detail/en/stmicroelectronics/STM32F412CEU6/497-18545-ND/6621739; Created_Date: 2019/10/28 15:48:57; ID: 30579; Library Name: Parts.DbLib; LifeCycle: New; Mfr Part Number: STM32F412CEU6; Package_Case: 48-UFQFN Exposed Pad; RoHS: Yes; Supplier: Digi-Key; Supplier Part Number: 497-18545-ND; Temperature Range: -40C to +85C (TA); Updated_Date: 2019/10/28 16:16:12; Value: STM32F412CEU6; Created By: aklimaj
U7 = 31087: Manufacturer: IQD Frequency Products; Mfr Part Description: XTAL OSC XO 8.0000MHZ HCMOS SMD; Part_Type: XTAL; Current_Rating: 10mA; Database Table Name: Parts; Updated By: aklimaj; Component Kind: Standard; ComponentLink1Description: Datasheet; ComponentLink1URL: https://www.iqdfrequencyproducts.com/products/pn/LFSPXO072801Reel.pdf; ComponentLink2Description: Supplier Part Details; ComponentLink2URL: https://www.digikey.com/en/products/detail/iqd-frequency-products/LFSPXO072801REEL/8633968; Created_Date: 2021/05/14 12:40:09; ID: 31087; Library Name: Parts.DbLib; LifeCycle: New; Mfr Part Number: LFSPXO072801REEL; Package_Case: 4-SMD, No Lead; RoHS: Yes; Supplier: Digi-Key; Supplier Part Number: 1923-1681-2-ND; Temperature Range: -40C to +85C; Updated_Date: 2021/05/14 12:44:40; Value: LFSPXO072801REEL; Created By: aklimaj
U8 = 31021: Manufacturer: TDK InvenSense; Mfr Part Description: MOTION SENSOR; Part_Type: MOTION; Database Table Name: Parts; Updated By: aklimaj; Component Kind: Standard; ComponentLink1Description: Datasheet; ComponentLink1URL: https://media.digikey.com/pdf/Data%20Sheets/TDK%20PDFs/ICM-42688-P_DS_Rev1.2.pdf; ComponentLink2Description: Supplier Part Details; ComponentLink2URL: https://www.digikey.com/products/en?keywords=ICM-42688-P; Created_Date: 2021/03/26 20:29:47; ID: 31021; Library Name: Parts.DbLib; LifeCycle: New; Mfr Part Number: ICM-42688-P; RoHS: Yes; Supplier: Digi-Key; Supplier Part Number: 1428-ICM-42688-PTR-ND; Updated_Date: 2021/03/26 20:53:04; Value: ICM-42688-P; Created By: aklimaj
U9 = 30798: Manufacturer: Bosch Sensortec; Mfr Part Description: SENSOR PRESSURE ABS; Part_Type: SENSOR; Database Table Name: Parts; Updated By: aklimaj; Voltage_Rating: 1.65V ~ 3.6V; Component Kind: Standard; ComponentLink1Description: Datasheet; ComponentLink1URL: https://www.bosch-sensortec.com/media/boschsensortec/downloads/datasheets/bst-bmp388-ds001.pdf; ComponentLink2Description: Supplier Part Details; ComponentLink2URL: https://www.digikey.com/product-detail/en/bosch-sensortec/BMP388/828-1079-1-ND/8322640; Created_Date: 2020/06/16 12:00:45; ID: 30798; Library Name: Parts.DbLib; LifeCycle: New; Mfr Part Number: BMP388; Package_Case: 10-WFLGA; RoHS: Yes; Supplier: Digi-Key; Supplier Part Number: 828-1079-1-ND; Updated_Date: 2020/06/16 12:28:45; Value: BMP388; Created By: aklimaj
U11 = 30946: Manufacturer: NXP USA Inc.; Mfr Part Description: IC AMP GALIL 1.559-1.61GHZ 6XSON; Part_Type: IC; Database Table Name: Parts; Updated By: aklimaj; Component Kind: Standard; ComponentLink1Description: Datasheet; ComponentLink1URL: https://www.nxp.com/docs/en/data-sheet/BGU8019.pdf; ComponentLink2Description: Supplier Part Details; ComponentLink2URL: https://www.digikey.com/products/en?keywords=BGU8019X; Created_Date: 2020/12/18 15:21:30; ID: 30946; Library Name: Parts.DbLib; LifeCycle: New; Mfr Part Number: BGU8019X; Package_Case: 6-XFDFN; RoHS: Yes; Supplier: Digi-Key; Supplier Part Number: 568-13127-2-ND; Updated_Date: 2020/12/18 15:27:59; Value: BGU8019X; Created By: aklimaj
